# Altium schematic sheet Osnovna shema.SchDoc("Osnovna shema")
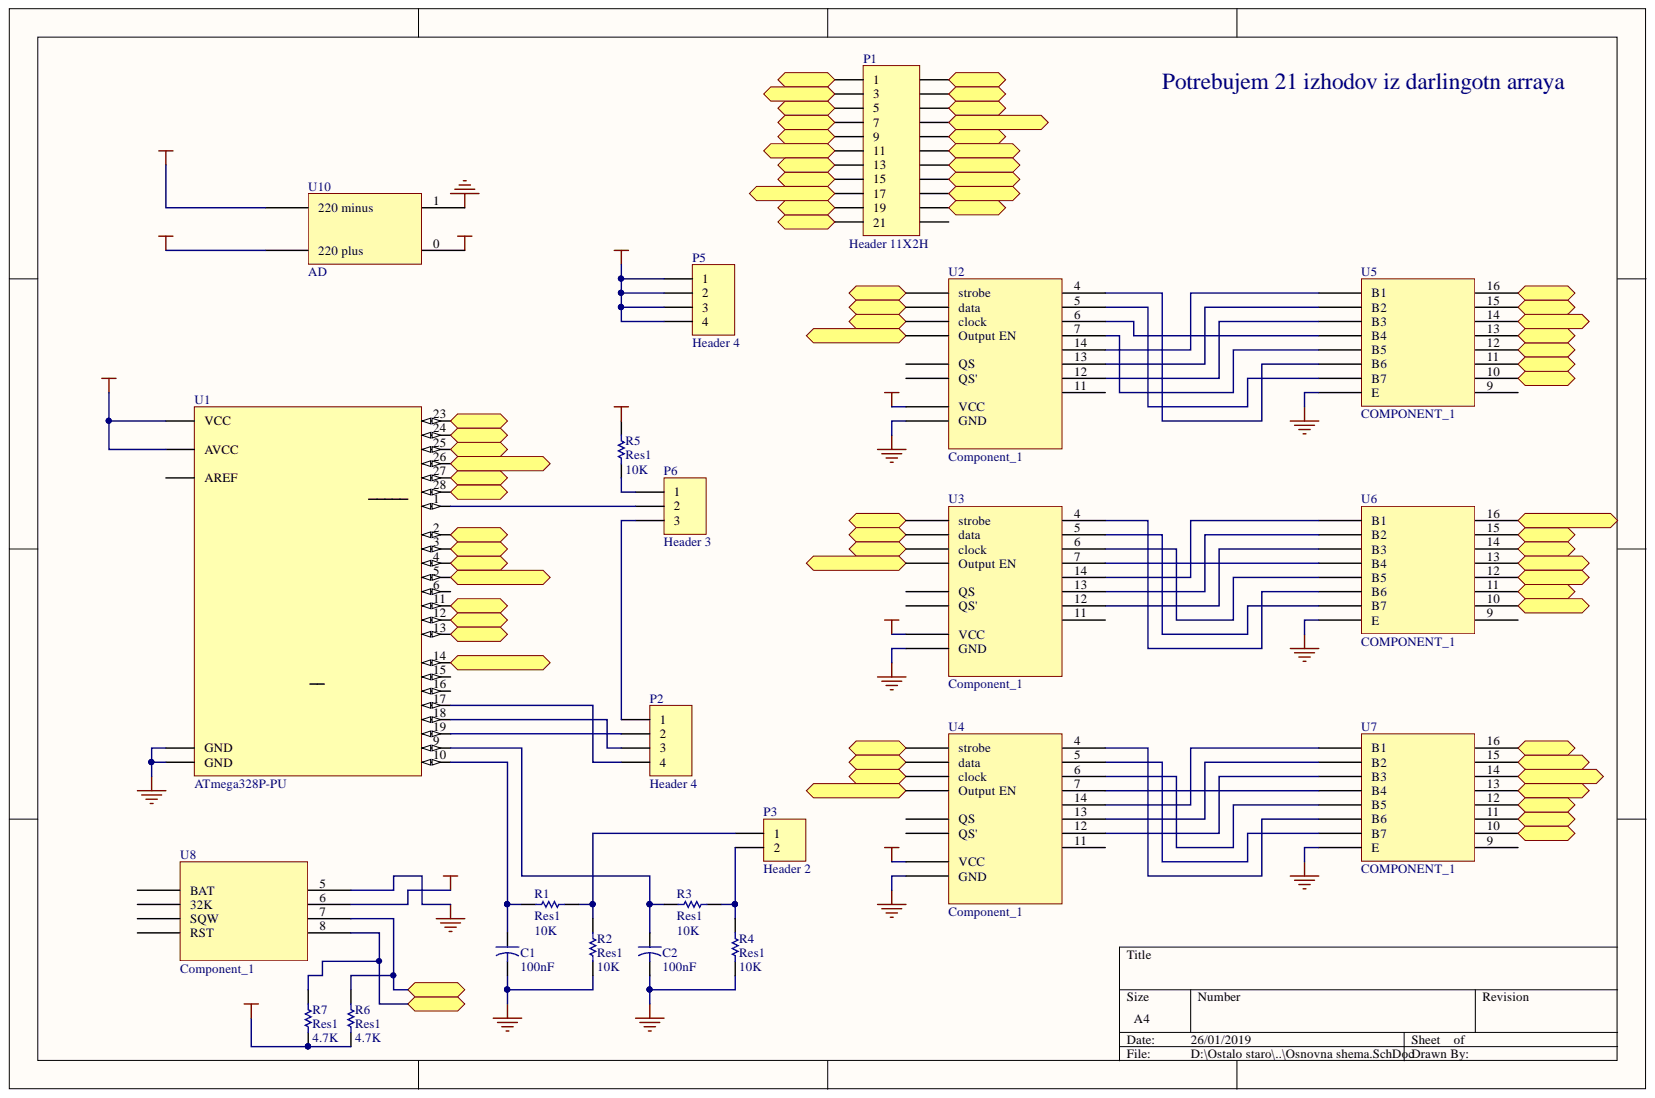
[diagram p0: Osnovna shema.SchDoc]

## Parts
C1  value 100nF  [CAPPR2.5-6.3x7]  (hidden comment: Electrolytic capacitor)
C2  value 100nF  [CAPPR2.5-6.3x7]  (hidden comment: Electrolytic capacitor)
P1  Header 11X2H  [HDR2X11H]
P2  Header 4  [HDR1X4]
P3  Header 2  [HDR1X2]
P5  Header 4  [HDR1X4]
P6  Header 3  [HDR1X3]
R1  Res1  value 10K  [AXIAL-0.3]
R2  Res1  value 10K  [AXIAL-0.3]
R3  Res1  value 10K  [AXIAL-0.3]
R4  Res1  value 10K  [AXIAL-0.3]
R5  Res1  value 10K  [AXIAL-0.3]
R6  Res1  value 4.7K  [AXIAL-0.3]
R7  Res1  value 4.7K  [AXIAL-0.3]
U1  ATmega328P-PU  [28P3]
U2  Component_1  [CD4094b]
U3  Component_1  [CD4094b]
U4  Component_1  [CD4094b]
U5  COMPONENT_1  [ULN2]
U6  COMPONENT_1  [ULN2]
U7  COMPONENT_1  [ULN2]
U8  Component_1  [PCBComponent_1]
U10  AD  [PCBComponent_1]

## Ports
clock1, clock2, clock3, data1, data2, data3, DESET, DESETm, DEVET, DO, DVANAJST, DVE, ENA, ENAJST, JE, MINUT, OSEM, OUTPUT EN1, OUTPUT EN2, OUTPUT EN3, PET, PETm, PETNAJST, POL, SCL, SDA, SEDEM, strobe1, strobe2, strobe3, TRI, URA, ČEZ, ŠEST, ŠTIRI

## Nets
5V: P5.1 P5.2 P5.3 P5.4 R5.2 R6.1 R7.1 U1.7(VCC) U1.20(AVCC) U2.16(VCC) U3.16(VCC) U4.16(VCC) U8.6 U10.0
GND: C1.2 C2.2 R2.1 R4.1 U1.8(GND) U1.22(GND) U2.8(GND) U3.8(GND) U4.8(GND) U5.8(E) U6.8(E) U7.8(E) U8.5 U10.1
VCC1: U10.3(220_minus)
VCC2: U10.2(220_plus)
clock1: U1.13 U2.3(clock) ; port clock1
clock2: U1.2 U3.3(clock) ; port clock2
clock3: U1.23 U4.3(clock) ; port clock3
data1: U1.12 U2.2(data) ; port data1
data2: U1.3 U3.2(data) ; port data2
data3: U1.24 U4.2(data) ; port data3
DESET: P1.12 U6.12 ; port DESET
DESETm: P1.16 U7.15 ; port DESETm
DEVET: P1.14 U6.10 ; port DEVET
DO: P1.21 U7.10 ; port DO
DVANAJST: P1.8 U6.16 ; port DVANAJST
DVE: P1.7 U5.10 ; port DVE
ENA: P1.6 U5.11 ; port ENA
ENAJST: P1.11 U6.13 ; port ENAJST
JE: P1.10 U6.14 ; port JE
MINUT: P1.18 U7.13 ; port MINUT
OSEM: P1.13 U6.11 ; port OSEM
OUTPUT EN1: U1.14 U2.15 ; port OUTPUT EN1
OUTPUT EN2: U1.5 U3.15 ; port OUTPUT EN2
OUTPUT EN3: U1.26 U4.15 ; port OUTPUT EN3
PET: P1.1 U5.16 ; port PET
PETm: P1.15 U7.16 ; port PETm
PETNAJST: P1.17 U7.14 ; port PETNAJST
POL: P1.19 U7.12 ; port POL
SCL: R6.2 U1.28 U8.7 ; port SCL
SDA: R7.2 U1.27 U8.8 ; port SDA
SEDEM: P1.3 U5.14 ; port SEDEM
strobe1: U1.11 U2.1(strobe) ; port strobe1
strobe2: U1.4 U3.1(strobe) ; port strobe2
strobe3: U1.25 U4.1(strobe) ; port strobe3
TRI: P1.4 U5.13 ; port TRI
URA: P1.9 U6.15 ; port URA
ČEZ: P1.20 U7.11 ; port ČEZ
ŠEST: P1.2 U5.15 ; port ŠEST
ŠTIRI: P1.5 U5.12 ; port ŠTIRI
NetC1_1: C1.1 R1.1 U1.10
NetC2_1: C2.1 R3.1 U1.9
NetP2_1: P2.1 P6.3
NetP2_2: P2.2 U1.19
NetP2_3: P2.3 U1.18
NetP2_4: P2.4 U1.17
NetP3_1: P3.1 R1.2 R2.2
NetP3_2: P3.2 R3.2 R4.2
NetP6_1: P6.1 R5.1
NetP6_2: P6.2 U1.1
NetU2_4: U2.4 U5.6(B6)
NetU2_5: U2.5 U5.7(B7)
NetU2_6: U2.6 U5.4(B4)
NetU2_7: U2.7 U5.5(B5)
NetU2_12: U2.12 U5.3(B3)
NetU2_13: U2.13 U5.2(B2)
NetU2_14: U2.14 U5.1(B1)
NetU3_4: U3.4 U6.6(B6)
NetU3_5: U3.5 U6.7(B7)
NetU3_6: U3.6 U6.5(B5)
NetU3_7: U3.7 U6.4(B4)
NetU3_12: U3.12 U6.3(B3)
NetU3_13: U3.13 U6.2(B2)
NetU3_14: U3.14 U6.1(B1)
NetU4_4: U4.4 U7.6(B6)
NetU4_5: U4.5 U7.7(B7)
NetU4_6: U4.6 U7.5(B5)
NetU4_7: U4.7 U7.4(B4)
NetU4_12: U4.12 U7.3(B3)
NetU4_13: U4.13 U7.2(B2)
NetU4_14: U4.14 U7.1(B1)

Not connected: P1 22; U1 6 15 16 21(AREF); U2 9(QS) 10(QS') 11; U3 9(QS) 10(QS') 11; U4 9(QS) 10(QS') 11; U5 9; U6 9; U7 9; U8 1(BAT) 2(32K) 3(SQW) 4(RST)

## Library data of the parts
C1 C2 = C El Rad 2.5 6.3x7: Capacitor; Signal Integrity: Model Name; Library Name: Capacitors.IntLib; Component Kind: Standard
P1 = Header 11X2H: Header, 11-Pin, Dual row, Right Angle; Signal Integrity: Connector; Library Name: Miscellaneous Connectors.IntLib; LatestRevisionNote: Re-released for DXP Platform.; LatestRevisionDate: 17-Jul-2002; Component Kind: Standard; Publisher: Altium Limited
P2 P5 = Header 4: Header, 4-Pin; Signal Integrity: Connector; Library Name: Miscellaneous Connectors.IntLib; LatestRevisionNote: Re-released for DXP Platform.; LatestRevisionDate: 17-Jul-2002; Component Kind: Standard; Publisher: Altium Limited
P3 = Header 2: Header, 2-Pin; Signal Integrity: Connector; Library Name: Miscellaneous Connectors.IntLib; LatestRevisionNote: Re-released for DXP Platform.; LatestRevisionDate: 17-Jul-2002; Component Kind: Standard; Publisher: Altium Limited
P6 = Header 3: Header, 3-Pin; Signal Integrity: Connector; Library Name: Miscellaneous Connectors.IntLib; LatestRevisionNote: Re-released for DXP Platform.; LatestRevisionDate: 17-Jul-2002; Component Kind: Standard; Publisher: Altium Limited
R1 R3 = Res1: Resistor; Value: 10K; Simulation: RESISTOR; Signal Integrity: Res; Published: 8-Jun-2000; PackageReference: AXIAL-0.3; PackageDescription: Axial Device, Thru-Hole; 2 Leads; 0.3 in Pin Spacing; Library Name: Miscellaneous Devices.IntLib; LatestRevisionNote: Re-released for DXP Platform.; LatestRevisionDate: 17-Jul-2002; Component Kind: Standard; Publisher: Altium Limited
R2 R4-R7 = Res1: Resistor; Simulation: RESISTOR; Signal Integrity: Res; Published: 8-Jun-2000; PackageReference: AXIAL-0.3; PackageDescription: Axial Device, Thru-Hole; 2 Leads; 0.3 in Pin Spacing; Library Name: Miscellaneous Devices.IntLib; LatestRevisionNote: Re-released for DXP Platform.; LatestRevisionDate: 17-Jul-2002; Component Kind: Standard; Publisher: Altium Limited
U1 = ATmega328P-PU: 8-bit AVR Microcontroller, 32KB Flash, 1KB EEPROM, 2KB SRAM, 28-pin PDIP, Industrial Grade (-40°C to 85°C); PackageVersion: revB, Sep-2001; PackageReference: 28P3; PackageDescription: 28-lead (0.300"/7.62mm Wide) Plastic Dual Inline Package (PDIP); Library Name: Atmel Microcontroller megaAVR.IntLib; DatasheetVersion: revD, May-2011; ComponentLink3URL: http://www.atmel.com/dyn/resources/prod_documents/8271S.pdf; ComponentLink3Description: ATmega48A/48PA/88A/88PA/168A/168PA/328/328P Summary; ComponentLink2URL: http://www.atmel.com/dyn/resources/prod_documents/8271.pdf; ComponentLink2Description: ATmega48A/48PA/88A/88PA/168A/168PA/328/328P Datasheet; ComponentLink1URL: http://www.atmel.com/; ComponentLink1Description: Manufacturer URL; Component Kind: Standard
U10 = Component_1: Component Kind: Standard; Library Name: 220vac to 5vdc.SchLib
U2-U4 U8 = Component_1: Component Kind: Standard
  U2-U4: Library Name: CD4094B.SchLib
  U8: Library Name: rtc2.SchLib
U5-U7 = COMPONENT_1: Component Kind: Standard; Library Name: ULNsch.SchLib
